# Altium schematic sheet typec_24pin.SchDoc
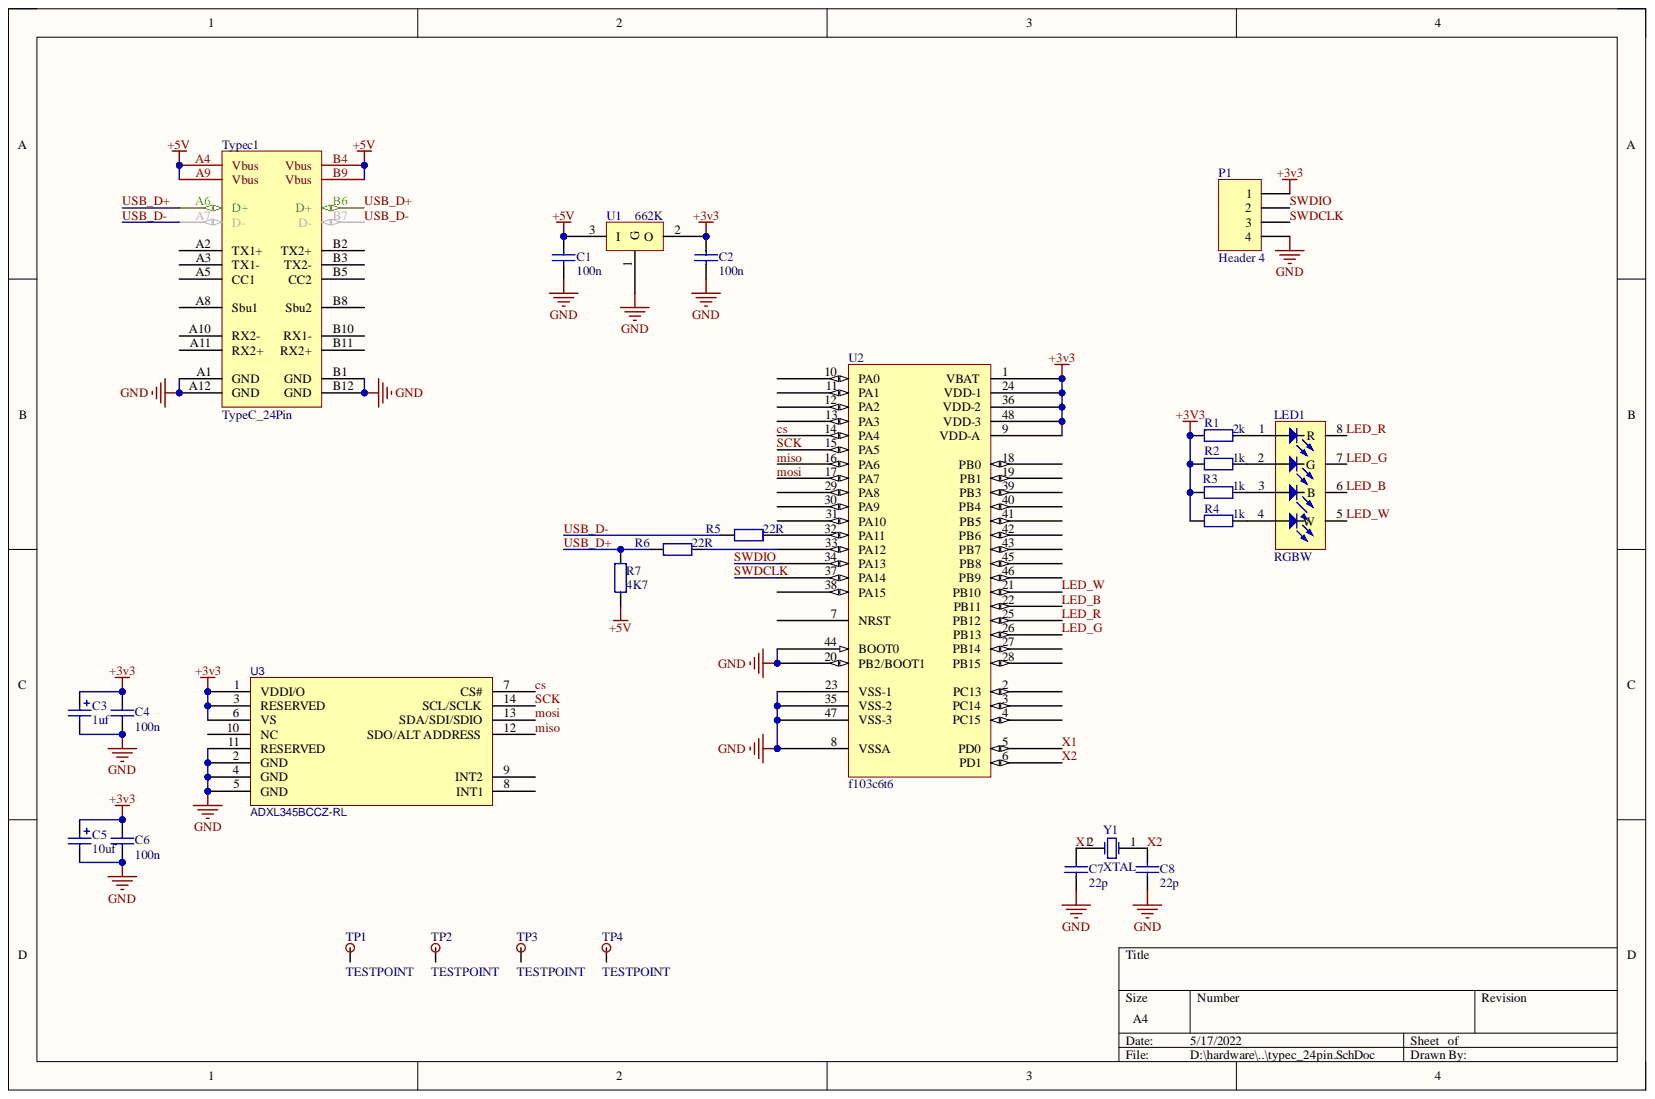
[diagram p0: typec_24pin.SchDoc]

## Parts
C1  100n  [0603C]
C2  100n  [0603C]
C3  1uf  [1206t]
C4  100n  [0603C]
C5  10uf  [3528B_TAN]
C6  100n  [0603C]
C7  22p  [0603C]
C8  22p  [0603C]
LED1  RGBW  [rgbw5050_8Pin]
P1  Header 4  [HDR1X4]
R1  2k  [0603r]
R2  1k  [0603r]
R3  1k  [0603r]
R4  1k  [0603r]
R5  22R  [0603R]
R6  22R  [0603R]
R7  4K7  [0603R]
TP1  TESTPOINT  [PAD2X4]
TP2  TESTPOINT  [PAD2X4]
TP3  TESTPOINT  [PAD2X4]
TP4  TESTPOINT  [PAD2X4]
Typec1  TypeC_24Pin  [typec立贴24P]
U1  662K  [SOT95P280X130-3N]
U2  f103c6t6  [TSQFP50P900X900X160-48M]
U3  ADXL345BCCZ-RL  [LGA-14_L5.0-W3.0-P0.80-BL-1]
Y1  XTAL  [XTAL5032N_2P]

## Nets
+3v3: C2.2 C3.1 C4.2 C5.1 C6.2 P1.1 R1.2 R2.2 R3.2 R4.2 U1.2 U2.1(VBAT) U2.9(VDD-A) U2.24(VDD-1) U2.36(VDD-2) U2.48(VDD-3) U3.1(VDDI/O) U3.3(RESERVED) U3.6(VS)
+5V: C1.2 R7.2 Typec1.A4(Vbus) Typec1.A9(Vbus) Typec1.B4(Vbus) Typec1.B9(Vbus) U1.3
GND: C1.1 C2.1 C3.2 C4.1 C5.2 C6.1 C7.1 C8.1 P1.4 Typec1.A1(GND) Typec1.A12(GND) Typec1.B1(GND) Typec1.B12(GND) U1.1 U2.8(VSSA) U2.20(PB2/BOOT1) U2.23(VSS-1) U2.35(VSS-2) U2.44(BOOT0) U2.47(VSS-3) U3.2(GND) U3.4(GND) U3.5(GND) U3.11(RESERVED)
cs: U2.14(PA4) U3.7(CS#)
LED_B: LED1.6(B) U2.22(PB11)
LED_G: LED1.7(G) U2.26(PB13)
LED_R: LED1.8(R) U2.25(PB12)
LED_W: LED1.5(W) U2.21(PB10)
miso: U2.16(PA6) U3.12(SDO/ALT_ADDRESS)
mosi: U2.17(PA7) U3.13(SDA/SDI/SDIO)
SCK: U2.15(PA5) U3.14(SCL/SCLK)
SWDCLK: P1.3 U2.37(PA14)
SWDIO: P1.2 U2.34(PA13)
USB_D+: R6.2 R7.1 Typec1.A6(D+) Typec1.B6(D+)
USB_D-: R5.2 Typec1.A7(D-) Typec1.B7(D-)
X1: C7.2 U2.5(PD0) Y1.2
X2: C8.2 U2.6(PD1) Y1.1
NetLED1_1: LED1.1 R1.1
NetLED1_2: LED1.2 R2.1
NetLED1_3: LED1.3 R3.1
NetLED1_4: LED1.4 R4.1
NetR5_1: R5.1 U2.32(PA11)
NetR6_1: R6.1 U2.33(PA12)

Not connected: TP1 1; TP2 1; TP3 1; TP4 1; Typec1 A2(TX1+) A3(TX1-) A5(CC1) A8(Sbu1) A10(RX2-) A11(RX2+) B2(TX2+) B3(TX2-) B5(CC2) B8(Sbu2) B10(RX1-) B11(RX2+); U2 2(PC13) 3(PC14) 4(PC15) 7(NRST) 10(PA0) 11(PA1) 12(PA2) 13(PA3) 18(PB0) 19(PB1) 27(PB14) 28(PB15) 29(PA8) 30(PA9) 31(PA10) 38(PA15) 39(PB3) 40(PB4) 41(PB5) 42(PB6) 43(PB7) 45(PB8) 46(PB9); U3 8(INT1) 9(INT2) 10(NC)

## Library data of the parts
C1 C2 C4 C6-C8 = Cap: 电容（径向）; Component Kind: Standard; LatestRevisionDate: 17-Jul-2002; LatestRevisionNote: Re-released for DXP Platform.; Library Name: 常用.SchLib; PackageDescription: Radial Cap, Thru-Hole; 2 Leads; 0.3 in Pin Spacing; PackageReference: RAD-0.3; Published: 8-Jun-2000; Signal Integrity: Cap; Simulation: CAP; Publisher: Altium Limited
C3 C5 = Cap Pol3: 有极性电容 贴片; Component Kind: Standard; LatestRevisionDate: 29-May-2009; LatestRevisionNote: IPC-7351 Footprint Added.; Library Name: 常用.SchLib; PackageDescription: Chip Capacitor; PackageReference: C0805; Published: 8-Jun-2000; Signal Integrity: Cap Pol; Simulation: CAP; Publisher: Altium Limited
LED1 = LEDRGBW: 5050贴片; Component Kind: Standard; Library Name: 通用元件库.SchLib
P1 = Header 4: Header, 4-Pin; Component Kind: Standard; LatestRevisionDate: 17-Jul-2002; LatestRevisionNote: Re-released for DXP Platform.; Signal Integrity: Connector; Library Name: 通用接插头符号.SchLib; Publisher: Altium Limited
R1-R4 = Res2: 电阻; Value: 0; Component Kind: Standard; LatestRevisionDate: 17-Jul-2002; LatestRevisionNote: Re-released for DXP Platform.; PackageDescription: Axial Device, Thru-Hole; 2 Leads; 0.4 in Pin Spacing; PackageReference: AXIAL-0.4; Published: 8-Jun-2000; Signal Integrity: Res; Simulation: RESISTOR; Library Name: 通用元件库.SchLib; Publisher: Altium Limited
R5-R7 = Res2: 电阻; Component Kind: Standard; LatestRevisionDate: 17-Jul-2002; LatestRevisionNote: Re-released for DXP Platform.; PackageDescription: Axial Device, Thru-Hole; 2 Leads; 0.4 in Pin Spacing; PackageReference: AXIAL-0.4; Published: 8-Jun-2000; Signal Integrity: Res; Simulation: RESISTOR; Library Name: 通用元件库.SchLib; Publisher: Altium Limited
U1 = XC6206P302MR: 3.0v ldo 400MA 0.4v压降; Component Kind: Standard; Library Name: 电源芯片.SchLib
U2 = stm32f103c6t6: Component Kind: Standard; Library Name: 常用.SchLib
U3 = ADXL345BCCZ-RL: BOM_Manufacturer: ADI(亚德诺)/LINEAR; Contributor: LCSC; BOM_JLCPCB Part Class: Extended Part; BOM_Manufacturer Part: ADXL345BCCZ-RL; BOM_Supplier: LCSC; BOM_Supplier Part: C579457; Component Kind: Standard; Library Name: 常用.SchLib; spicePre: U; spiceSymbolName: ADXL345BCCZ-RL
Y1 = XTAL_Passive_2P: 无源晶振; Component Kind: Standard; LatestRevisionDate: 17-Jul-2002; LatestRevisionNote: Re-released for DXP Platform.; Library Name: 常用.SchLib; PackageDescription: Crystal, Thru-Hole; 2 Leads; Body 3.1 x 8.2 mm (Dia.xH); PackageReference: R38; Published: 8-Jun-2000; Publisher: Altium Limited
TP1-TP4 = TESTPOINT: Component Kind: Standard; Library Name: 常用.SchLib
Typec1 = TypeC_24Pin: Component Kind: Standard; Library Name: 接插头.SchLib
